# Altium schematic sheet Sheet1.SchDoc
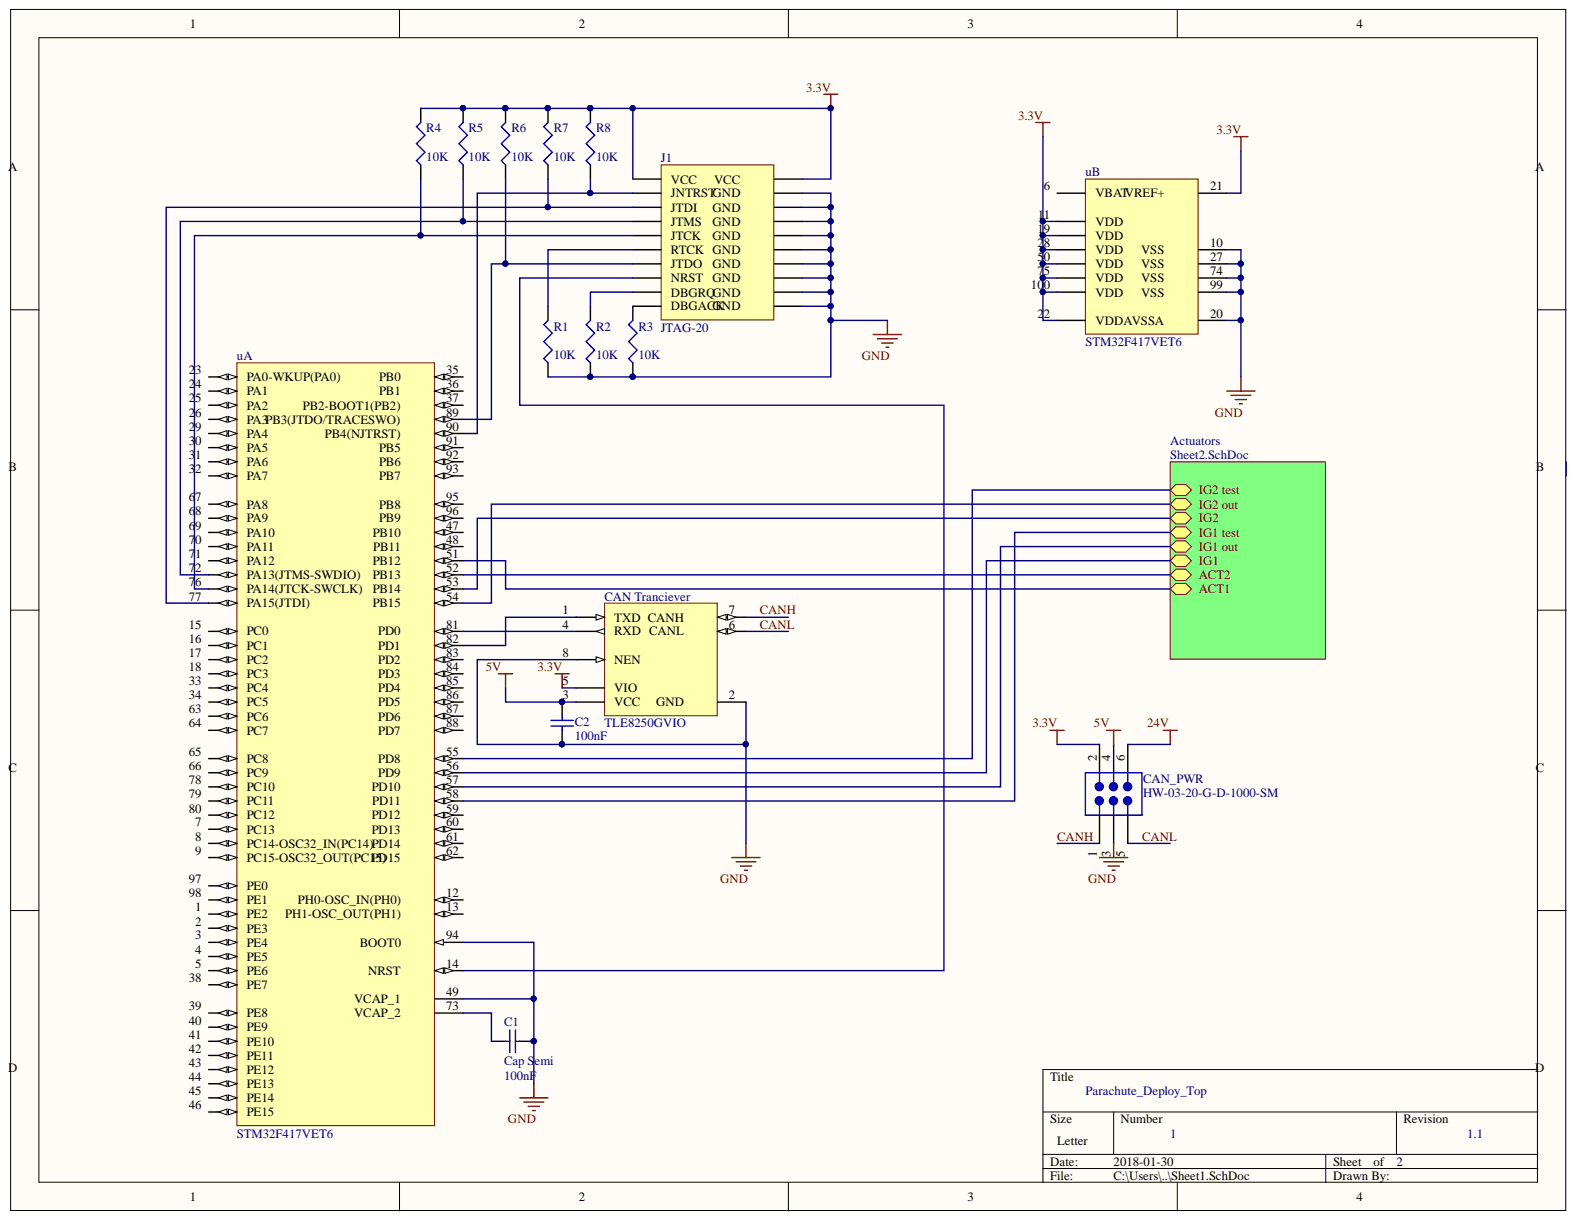
[diagram p0: Sheet1.SchDoc]

## Parts
C1  Cap Semi  value 100nF  [C1206]
C2  value 100nF  [C1206]  (hidden comment: Cap Semi)
CAN_Tranciever  TLE8250GVIO  [INF-PG-DSO-8-16_N]
CAN_PWR  HW-03-20-G-D-1000-SM  [SMTC-HW-03-20-X-D-1000-SM]
J1  JTAG-20  [PCBComponent_1]
R1  value 10K  [J1-0603]  (hidden comment: RTCK PD)
R2  value 10K  [J1-0603]  (hidden comment: DBGRQ PD)
R3  value 10K  [J1-0603]  (hidden comment: DBGACK PD)
R4  value 10K  [J1-0603]  (hidden comment: RTCK PD)
R5  value 10K  [J1-0603]  (hidden comment: RTCK PD)
R6  value 10K  [J1-0603]  (hidden comment: RTCK PD)
R7  value 10K  [J1-0603]  (hidden comment: RTCK PD)
R8  value 10K  [J1-0603]  (hidden comment: RTCK PD)
u  STM32F417VET6  [STM-LQFP100_N]  (parts A,B)

## Nets
3.3V: CAN_Tranciever.5(VIO) CAN_PWR.2 J1.1(VCC) J1.2 R4.2 R5.2 R6.2 R7.2 R8.2 u.11(VDD) u.19(VDD) u.21 u.22 u.28(VDD) u.50(VDD) u.75(VDD) u.100(VDD)
5V: C2.2 CAN_Tranciever.3(VCC) CAN_PWR.4
24V: CAN_PWR.6
GND: C1.2 C2.1 CAN_Tranciever.2 CAN_Tranciever.8(NEN) CAN_PWR.3 J1.4 J1.6 J1.8 J1.10 J1.12 J1.14 J1.16 J1.18 J1.20 R1.1 R2.1 R3.1 u.10 u.20 u.27 u.49 u.74 u.94 u.99
CANH: CAN_Tranciever.7 CAN_PWR.1
CANL: CAN_Tranciever.6 CAN_PWR.5
NetC1_1: C1.1 u.73
NetCAN Tranciever_1: CAN_Tranciever.1(TXD) u.82
NetCAN Tranciever_4: CAN_Tranciever.4(RXD) u.81
NetJ1_3: J1.3(JNTRSTGND) R8.1 u.90
NetJ1_5: J1.5(JTDI) R7.1 u.77(PA15(JTDI))
NetJ1_7: J1.7(JTMS) R5.1 u.72(PA13(JTMS-SWDIO))
NetJ1_9: J1.9(JTCK) R4.1 u.76(PA14(JTCK-SWCLK))
NetJ1_11: J1.11(RTCK) R1.2
NetJ1_13: J1.13(JTDO) R6.1 u.89
NetJ1_15: J1.15(NRST) u.14
NetJ1_17: J1.17(DBGRQGND) R2.2
NetJ1_19: J1.19(DBGACK) R3.2
Netu_1: u.1(PE2)
Netu_2: u.2(PE3)
Netu_3: u.3(PE4)
Netu_4: u.4(PE5)
Netu_5: u.5(PE6)
Netu_6: u.6(VBAT)
Netu_7: u.7(PC13)
Netu_8: u.8(PC14-OSC32_IN(PC14))
Netu_9: u.9(PC15-OSC32_OUT(PC15))
Netu_12: u.12
Netu_13: u.13
Netu_15: u.15(PC0)
Netu_16: u.16(PC1)
Netu_17: u.17(PC2)
Netu_18: u.18(PC3)
Netu_23: u.23(PA0-WKUP(PA0))
Netu_24: u.24(PA1)
Netu_25: u.25(PA2)
Netu_26: u.26(PA3)
Netu_29: u.29(PA4)
Netu_30: u.30(PA5)
Netu_31: u.31(PA6)
Netu_32: u.32(PA7)
Netu_33: u.33(PC4)
Netu_34: u.34(PC5)
Netu_35: u.35
Netu_36: u.36
Netu_37: u.37
Netu_38: u.38(PE7)
Netu_39: u.39(PE8)
Netu_40: u.40(PE9)
Netu_41: u.41(PE10)
Netu_42: u.42(PE11)
Netu_43: u.43(PE12)
Netu_44: u.44(PE13)
Netu_45: u.45(PE14)
Netu_46: u.46(PE15)
Netu_47: u.47
Netu_48: u.48
Netu_51: u.51
Netu_52: u.52
Netu_53: u.53
Netu_54: u.54
Netu_55: u.55
Netu_56: u.56
Netu_57: u.57
Netu_58: u.58
Netu_59: u.59
Netu_60: u.60
Netu_61: u.61
Netu_62: u.62
Netu_63: u.63(PC6)
Netu_64: u.64(PC7)
Netu_65: u.65(PC8)
Netu_66: u.66(PC9)
Netu_67: u.67(PA8)
Netu_68: u.68(PA9)
Netu_69: u.69(PA10)
Netu_70: u.70(PA11)
Netu_71: u.71(PA12)
Netu_78: u.78(PC10)
Netu_79: u.79(PC11)
Netu_80: u.80(PC12)
Netu_83: u.83
Netu_84: u.84
Netu_85: u.85
Netu_86: u.86
Netu_87: u.87
Netu_88: u.88
Netu_91: u.91
Netu_92: u.92
Netu_93: u.93
Netu_95: u.95
Netu_96: u.96
Netu_97: u.97(PE0)
Netu_98: u.98(PE1)
N00007:

## Library data of the parts
C1 C2 = Cap Semi: Capacitor (Semiconductor SIM Model); Component Kind: Standard; LatestRevisionDate: 29-May-2009; LatestRevisionNote: IPC-7351 Footprint Added.; Library Name: Miscellaneous Devices.IntLib; PackageDescription: Chip Capacitor; PackageReference: C1206; Published: 8-Jun-2000; Signal Integrity: Cap Semi; Simulation: CAP; Publisher: Altium Limited
CAN_Tranciever = TLE8250GVIO: High Speed CAN-Transceiver, 1 Mbit/s Transmission Rate, PG-DSO-8-16, Reel, Green; Manufacturer: Infineon; Bus Wake-up capability: FALSE; Green: TRUE; Quiescent Current (max.): < 15 uA @ 5V standby; Standards: ISO11898-2; Wake-up inputs: no; Component Kind: Standard; ComponentLink1Description: Manufacturer URL; ComponentLink1URL: http://www.infineon.com/; ComponentLink2Description: Datasheet; ComponentLink2URL: http://www.infineon.com/dgdl/TLE8250GVIO_DS_Rev10.pdf?folderId=db3a30431ed1d7b2011edadb13813703&fileId=db3a30432b57a660012b67ea91880b46&location=en.Products.Automotive_ICs.Automotive_Transceivers.CAN_Transceivers.DATASHEET.TLE8250GVIO_DS_Rev10.pdf; ComponentLink3Description: Package Specification; ComponentLink3URL: http://www.infineon.com/dgdl/TLE7259-2GE_DS_rev12.pdf?folderId=db3a3043163797a6011666d32a0c0de1&fileId=db3a3043163797a6011666d4dbb80de2&location=en.Products.Automotive_ICs.Automotive_Transceivers.LIN_Transceivers.DATASHEET.TLE7259-2GE_DS_rev12.pdf; DatasheetVersion: Rev. 1.0; Group: High Speed; Halogen-free: TRUE; Library Name: Infineon_Transceiver.IntLib; Mounting Technology: Surface Mount; PackageDescription: 8-Pin Plastic (Green) Dual Small Outline Package, 4.9 x 3.9 mm Body, 1.27 mm Pitch; PackageReference: PG-DSO-8-16; PackageVersion: Mar-07; Packing: Reel; PartNumber: TLE8250GVIO; Supplier 1: Mouser; Supplier 2: Digi-Key; Supplier Part Number 1: 726-TLE8250GVIO; Supplier Part Number 2: TLE8250GVIO-ND; Transmission rate max: 1 Mbit/s
CAN_PWR = HW-03-20-G-D-1000-SM: Flexible .025" SQ Board Stacker, Surface Mount, Vertical, -55 to 125 degC, 2.54 mm Pitch, 6-Pin, Male, RoHS; Manufacturer: Samtec; Pitch: 2.54 mm; Component Kind: Standard; ComponentLink1Description: Datasheet; ComponentLink1URL: http://www.samtec.com/documents/webfiles/pdf/HW_SM.PDF; ComponentLink2Description: Package Specification; ComponentLink2URL: http://www.samtec.com/documents/webfiles/cpdf/HW-XX-XX-XX-X-XXXX-SM-X-XXX-MKT.pdf; DatasheetVersion: F-214; Mounting Technology: Surface Mount; Orientation: Vertical; PackageDescription: HW Board to Board Assembly; PackageReference: HW-03-20-X-D-1000-SM; PackageVersion: AW, 3/1993; PartNumber: HW-03-20-G-D-1000-SM; RoHS: True; Temperature Range: -55 to 125 degC; Library Name: Samtec_2,54_mm_Pitch_Terminal_Strips.IntLib
J1 = HEADER 10X2: 20-pin JTAG header; Component Kind: Standard; Library Name: Rocket_Connectors.SchLib
R1-R8 = Res3: Resistor; Component Kind: Standard; LatestRevisionDate: 29-May-2009; LatestRevisionNote: IPC-7351 Footprint Added.; Library Name: Miscellaneous Devices.IntLib; PackageDescription: Chip Resistor; PackageReference: J1-0603; Published: 8-Jun-2000; Signal Integrity: Res; Simulation: RESISTOR; Publisher: Altium Limited
u = STM32F417VET6: ARM Cortex-M4 32-bit MCU+FPU, 210 DMIPS, 512 kB Flash, 192 kB Internal RAM, 82 I/Os, 100-pin LQFP, -40 to 85 degC, Tray; Manufacturer: STMicroelectronics; D/A Converter: 2x12-bit; FLASH Size (Prog) (kB): 512; I/Os (High Current): 82; Internal RAM Size (kB): 192; Operating Frequency(F) (Processor speed) (MHz): 168; Supply Voltage(Vcc) max (V): 3.6; Supply Voltage(Vcc) min (V): 1.8; Component Kind: Standard; ComponentLink1Description: Manufacturer URL; ComponentLink1URL: http://www.st.com/web/en/home.html; ComponentLink2Description: Datasheet; ComponentLink2URL: http://www.st.com/st-web-ui/static/active/en/resource/technical/document/datasheet/DM00035129.pdf; ComponentLink3Description: Package Specification; ComponentLink3URL: http://www.st.com/st-web-ui/static/active/en/resource/technical/document/data_brief/DM00071938.pdf; Core: ARM Cortex-M4; DatasheetVersion: Rev. 4; Library Name: STMicroelectronics STM32 F4.IntLib; Mounting Technology: Surface Mount; PackageDescription: 100-Pin Low Profile Quad Flat Package, 14 x 14 mm Body, 0.5 mm Pitch; PackageReference: LQFP100; PackageVersion: Rev. 1, 06/2013; Packing: Tray; PartNumber: STM32F417VET6; Supplier 1: Digi-Key; Supplier 2: Farnell; Supplier 3: Newark; Supplier 4: Newark; Supplier 5: Mouser; Supplier Part Number 1: 497-11901-ND; Supplier Part Number 2: 2333307; Supplier Part Number 3: 99W9922; Supplier Part Number 4: 94T6496; Supplier Part Number 5: 511-STM32F417VET6
